# Altium schematic sheet Sch03_GPIO.SchDoc(Sch03_GPIO)
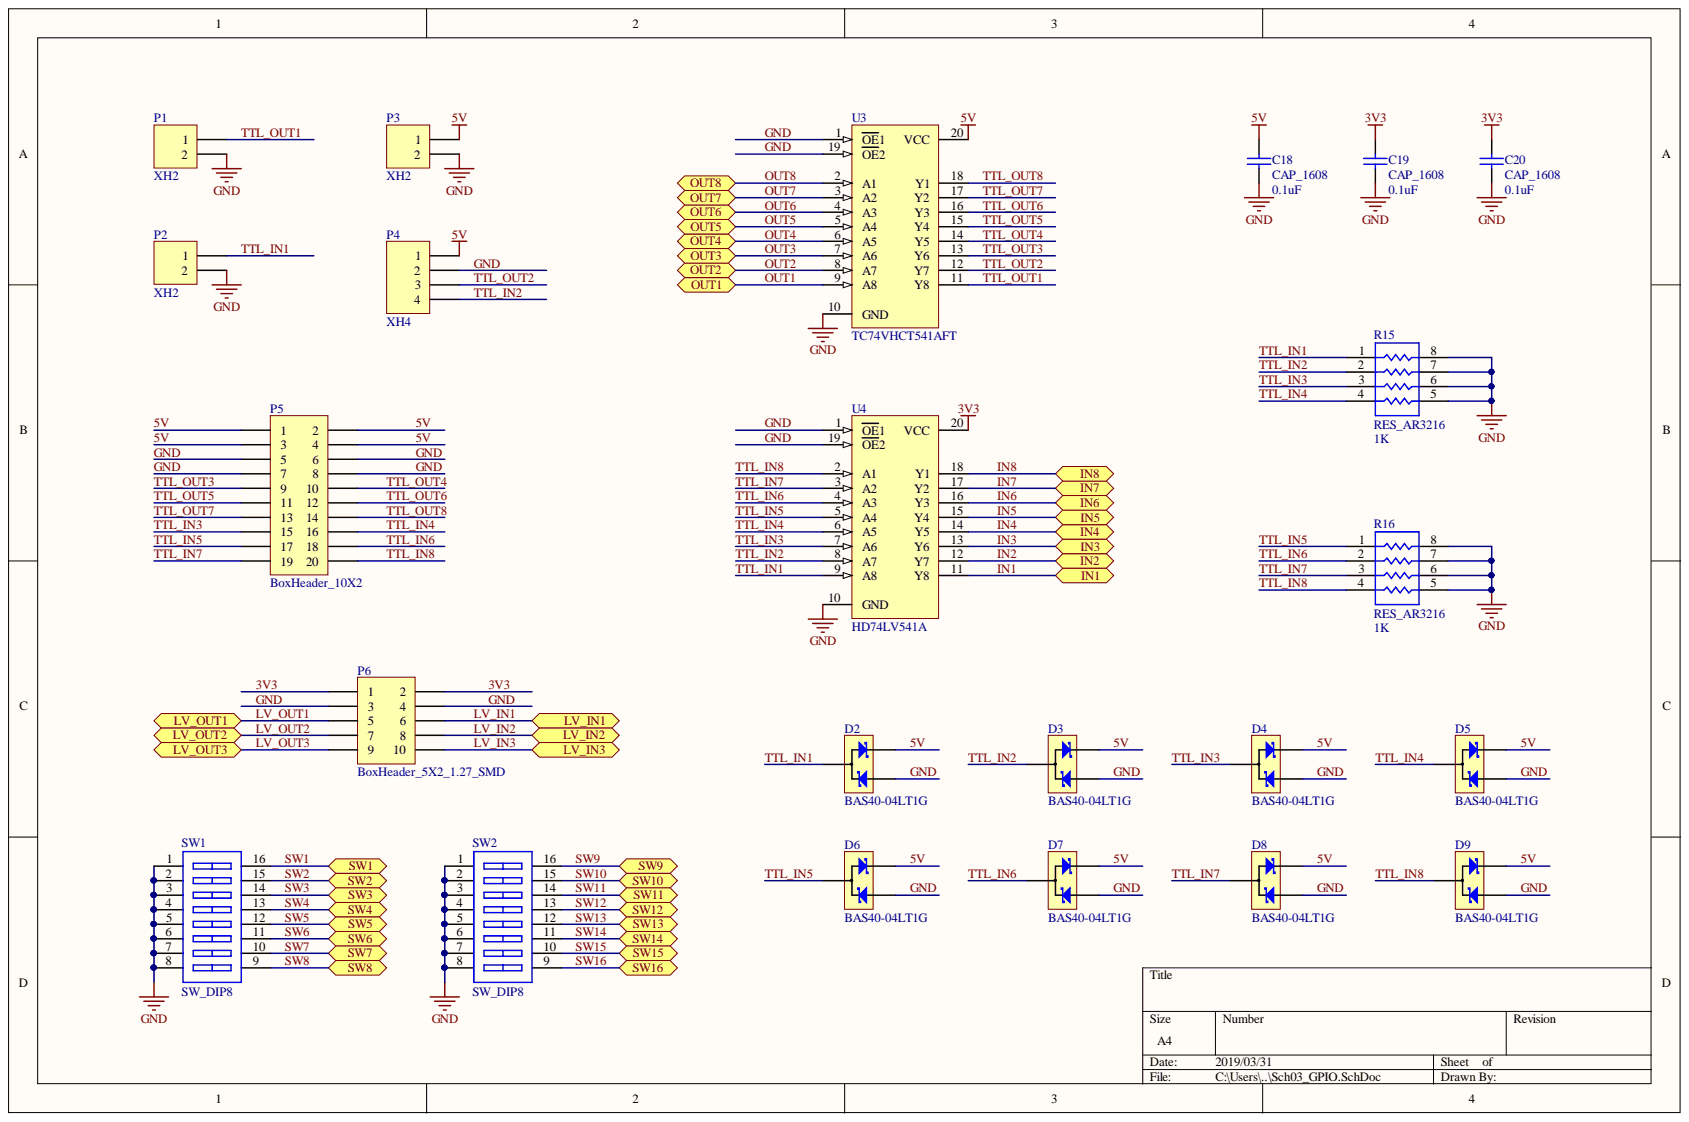
[diagram p0: Sch03_GPIO.SchDoc]

## Parts
C18  CAP_1608  value 0.1uF  [1608C]
C19  CAP_1608  value 0.1uF  [1608C]
C20  CAP_1608  value 0.1uF  [1608C]
D2  BAS40-04LT1G  [SOT23-3]
D3  BAS40-04LT1G  [SOT23-3]
D4  BAS40-04LT1G  [SOT23-3]
D5  BAS40-04LT1G  [SOT23-3]
D6  BAS40-04LT1G  [SOT23-3]
D7  BAS40-04LT1G  [SOT23-3]
D8  BAS40-04LT1G  [SOT23-3]
D9  BAS40-04LT1G  [SOT23-3]
P1  XH2  [XH2]
P2  XH2  [XH2]
P3  XH2  [XH2]
P4  XH4  [XH4]
P5  BoxHeader_10X2  [BOXH_2X10]
P6  BoxHeader_5X2_1.27_SMD  [BOXH_2X5_1.27_SMD]
R15  RES_AR3216  value 1K  [SO8_M]
R16  RES_AR3216  value 1K  [SO8_M]
SW1  SW_DIP8  [SOP16_DIPSW]
SW2  SW_DIP8  [SOP16_DIPSW]
U3  TC74VHCT541AFT  [TSSOP20]
U4  HD74LV541A  [TSSOP20]

## Ports
IN1, IN2, IN3, IN4, IN5, IN6, IN7, IN8, LV_IN1, LV_IN2, LV_IN3, LV_OUT1, LV_OUT2, LV_OUT3, OUT1, OUT2, OUT3, OUT4, OUT5, OUT6, OUT7, OUT8, SW1, SW2, SW3, SW4, SW5, SW6, SW7, SW8, SW9, SW10, SW11, SW12, SW13, SW14, SW15, SW16

## Nets
3V3: C19.1 C20.1 P6.1 P6.2 U4.20(VCC)
5V: C18.1 D2.2 D3.2 D4.2 D5.2 D6.2 D7.2 D8.2 D9.2 P3.1 P4.1 P5.1 P5.2 P5.3 P5.4 U3.20(VCC)
GND: C18.2 C19.2 C20.2 D2.1 D3.1 D4.1 D5.1 D6.1 D7.1 D8.1 D9.1 P1.2 P2.2 P3.2 P4.2 P5.5 P5.6 P5.7 P5.8 P6.3 P6.4 R15.5 R15.6 R15.7 R15.8 R16.5 R16.6 R16.7 R16.8 SW1.1 SW1.2 SW1.3 SW1.4 SW1.5 SW1.6 SW1.7 SW1.8 SW2.1 SW2.2 SW2.3 SW2.4 SW2.5 SW2.6 SW2.7 SW2.8 U3.1(OE1) U3.10(GND) U3.19(OE2) U4.1(OE1) U4.10(GND) U4.19(OE2)
IN1: U4.11(Y8) ; port IN1
IN2: U4.12(Y7) ; port IN2
IN3: U4.13(Y6) ; port IN3
IN4: U4.14(Y5) ; port IN4
IN5: U4.15(Y4) ; port IN5
IN6: U4.16(Y3) ; port IN6
IN7: U4.17(Y2) ; port IN7
IN8: U4.18(Y1) ; port IN8
LV_IN1: P6.6 ; port LV_IN1
LV_IN2: P6.8 ; port LV_IN2
LV_IN3: P6.10 ; port LV_IN3
LV_OUT1: P6.5 ; port LV_OUT1
LV_OUT2: P6.7 ; port LV_OUT2
LV_OUT3: P6.9 ; port LV_OUT3
OUT1: U3.9(A8) ; port OUT1
OUT2: U3.8(A7) ; port OUT2
OUT3: U3.7(A6) ; port OUT3
OUT4: U3.6(A5) ; port OUT4
OUT5: U3.5(A4) ; port OUT5
OUT6: U3.4(A3) ; port OUT6
OUT7: U3.3(A2) ; port OUT7
OUT8: U3.2(A1) ; port OUT8
SW1: SW1.16 ; port SW1
SW2: SW1.15 ; port SW2
SW3: SW1.14 ; port SW3
SW4: SW1.13 ; port SW4
SW5: SW1.12 ; port SW5
SW6: SW1.11 ; port SW6
SW7: SW1.10 ; port SW7
SW8: SW1.9 ; port SW8
SW9: SW2.16 ; port SW9
SW10: SW2.15 ; port SW10
SW11: SW2.14 ; port SW11
SW12: SW2.13 ; port SW12
SW13: SW2.12 ; port SW13
SW14: SW2.11 ; port SW14
SW15: SW2.10 ; port SW15
SW16: SW2.9 ; port SW16
TTL_IN1: D2.3 P2.1 R15.1 U4.9(A8)
TTL_IN2: D3.3 P4.4 R15.2 U4.8(A7)
TTL_IN3: D4.3 P5.15 R15.3 U4.7(A6)
TTL_IN4: D5.3 P5.16 R15.4 U4.6(A5)
TTL_IN5: D6.3 P5.17 R16.1 U4.5(A4)
TTL_IN6: D7.3 P5.18 R16.2 U4.4(A3)
TTL_IN7: D8.3 P5.19 R16.3 U4.3(A2)
TTL_IN8: D9.3 P5.20 R16.4 U4.2(A1)
TTL_OUT1: P1.1 U3.11(Y8)
TTL_OUT2: P4.3 U3.12(Y7)
TTL_OUT3: P5.9 U3.13(Y6)
TTL_OUT4: P5.10 U3.14(Y5)
TTL_OUT5: P5.11 U3.15(Y4)
TTL_OUT6: P5.12 U3.16(Y3)
TTL_OUT7: P5.13 U3.17(Y2)
TTL_OUT8: P5.14 U3.18(Y1)

## Library data of the parts
C18-C20 = CAP_1608: Capacitor (Semiconductor SIM Model); Published: 8-Jun-2000; PackageReference: C1206; PackageDescription: Chip Capacitor; LatestRevisionNote: IPC-7351 Footprint Added.; LatestRevisionDate: 29-May-2009; Component Kind: Standard; Publisher: Altium Limited; Library Name: DRSSTC_SFP_Transceiver_Lib.IntLib
D2-D9 = BAS40-04LT1G: 3pin Diode Bridge; Published: 8-Jun-2000; PackageReference: D-38; PackageDescription: Bridge Rectifier; 4 Terminals; Body 9.4 x 9.4 mm (typ); Pitch 5.08 mm; LatestRevisionNote: Sch pins A & K swapped.; LatestRevisionDate: 29-May-2009; DatasheetVersion: E2732B; Component Kind: Standard; Publisher: Altium Limited; Library Name: DRSSTC_SFP_Transceiver_Lib.IntLib
P1-P3 = XH2: Header, 2-Pin; Signal Integrity: Connector; LatestRevisionNote: Re-released for DXP Platform.; LatestRevisionDate: 17-Jul-2002; Component Kind: Standard; Publisher: Altium Limited; Library Name: DRSSTC_SFP_Transceiver_Lib.IntLib
P4 = XH4: Header, 4-Pin; Signal Integrity: Connector; LatestRevisionNote: Re-released for DXP Platform.; LatestRevisionDate: 17-Jul-2002; Component Kind: Standard; Publisher: Altium Limited; Library Name: DRSSTC_SFP_Transceiver_Lib.IntLib
P5 = BoxHeader_10X2: Header, 10-Pin, Dual row; Signal Integrity: Connector; LatestRevisionNote: Re-released for DXP Platform.; LatestRevisionDate: 17-Jul-2002; Component Kind: Standard; Publisher: Altium Limited; Library Name: DRSSTC_SFP_Transceiver_Lib.IntLib
P6 = BoxHeader_5X2_1.27_SMD: Header, 5-Pin, Dual row; Component Kind: Standard; Library Name: DRSSTC_SFP_Transceiver_Lib.IntLib
R15 R16 = RES_AR3216: Resistor Array; Component Kind: Standard; Library Name: DRSSTC_SFP_Transceiver_Lib.IntLib
SW1 SW2 = SW_DIP8: DIP Switch, 8 Position, SPST; Published: 24-Mar-1999; PackageReference: DIP_SW_8WAY_SMD; PackageDescription: DIP Switch; 16 Leads; LatestRevisionNote: Re-released for DXP Platform.; LatestRevisionDate: 17-Jul-2002; Component Kind: Standard; Publisher: Altium Limited; Library Name: DRSSTC_SFP_Transceiver_Lib.IntLib
U3 = TC74VHCT541AFT: Octal Buffer/Driver with 3-State Outputs; Published: 8-Jun-2000; PackageVersion: May-1999; PackageReference: DB020; PackageDescription: 20-Pin Plastic Small Outline Package 0.65 mm Pitch; LatestRevisionNote: IPC-7351 Footprint Added.; LatestRevisionDate: 16-Oct-2006; DatasheetVersion: Jul-1995; ComponentLink1URL: http://www.ti.com/; ComponentLink1Description: Manufacturer Link; Component Kind: Standard; Code_JEDEC: MO-150-AE; Code_IPC: SOP65P780-20; Publisher: Altium Limited; Library Name: DRSSTC_SFP_Transceiver_Lib.IntLib
U4 = HD74LV541A: Octal Buffer/Driver with 3-State Outputs; Published: 8-Jun-2000; PackageVersion: May-1999; PackageReference: DB020; PackageDescription: 20-Pin Plastic Small Outline Package 0.65 mm Pitch; LatestRevisionNote: IPC-7351 Footprint Added.; LatestRevisionDate: 16-Oct-2006; DatasheetVersion: Jul-1995; ComponentLink1URL: http://www.ti.com/; ComponentLink1Description: Manufacturer Link; Component Kind: Standard; Code_JEDEC: MO-150-AE; Code_IPC: SOP65P780-20; Publisher: Altium Limited; Library Name: DRSSTC_SFP_Transceiver_Lib.IntLib
